# Altium schematic sheet [6]CH340C.SchDoc
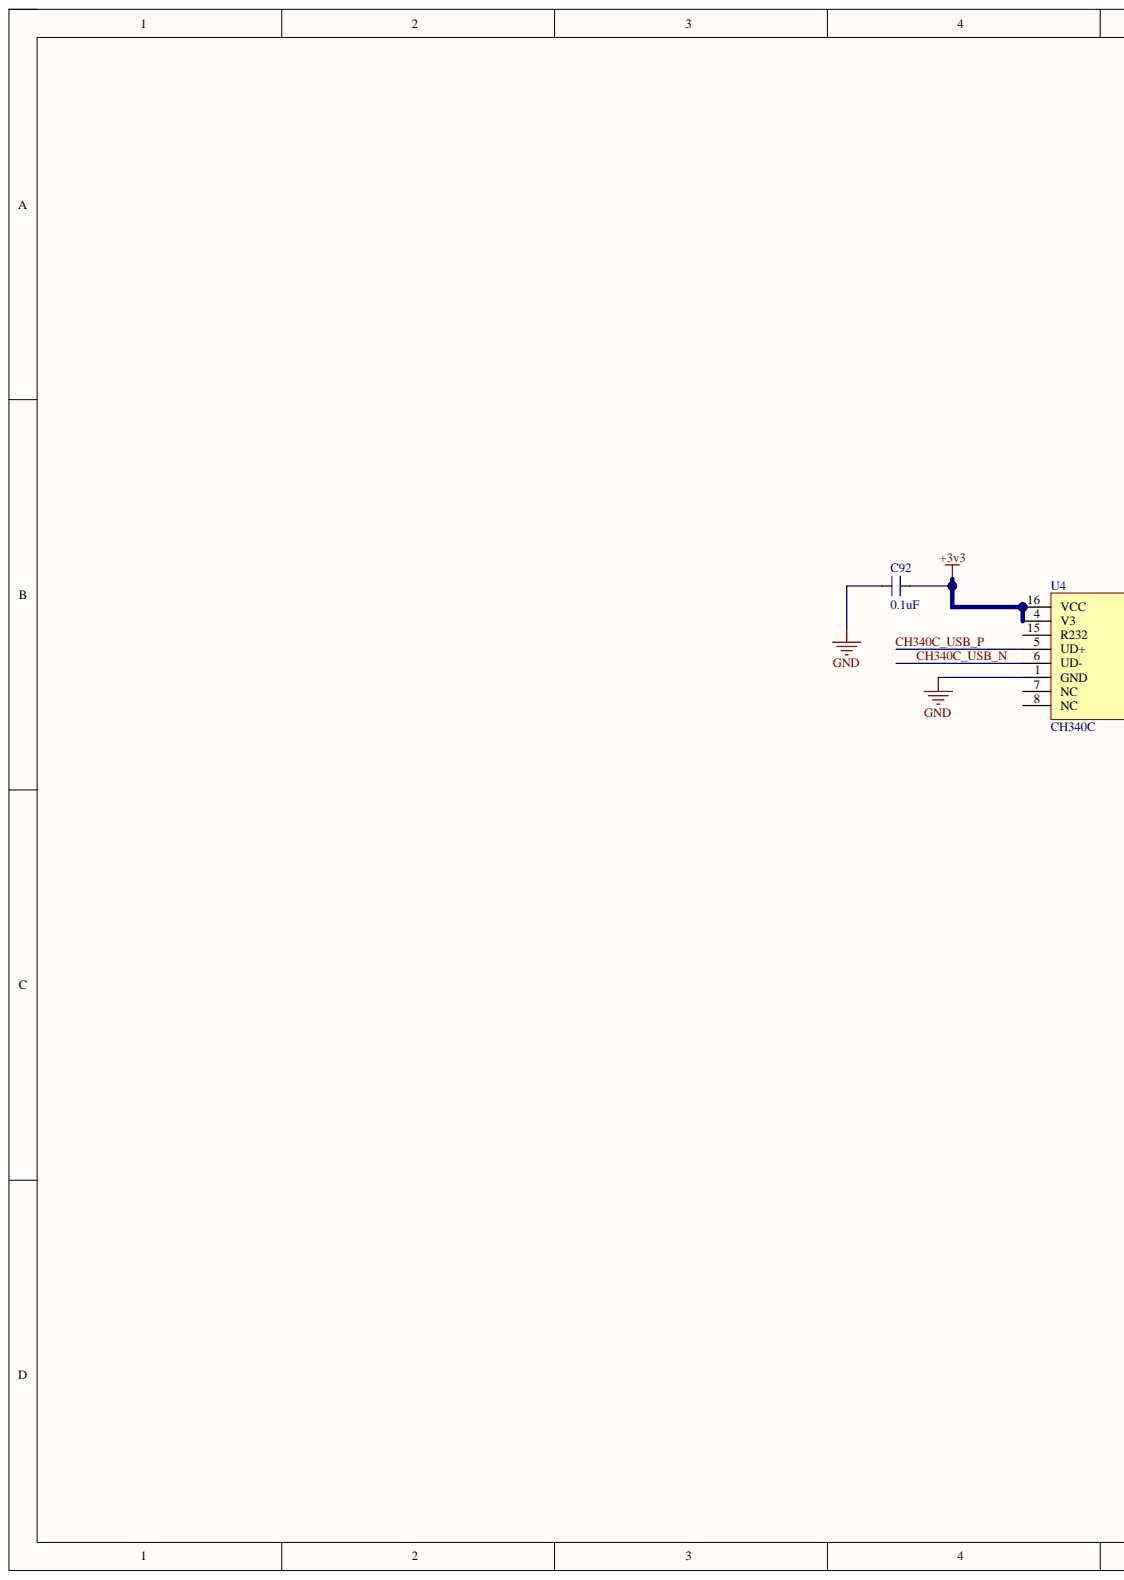
[diagram p0: [6]CH340C.SchDoc, left]
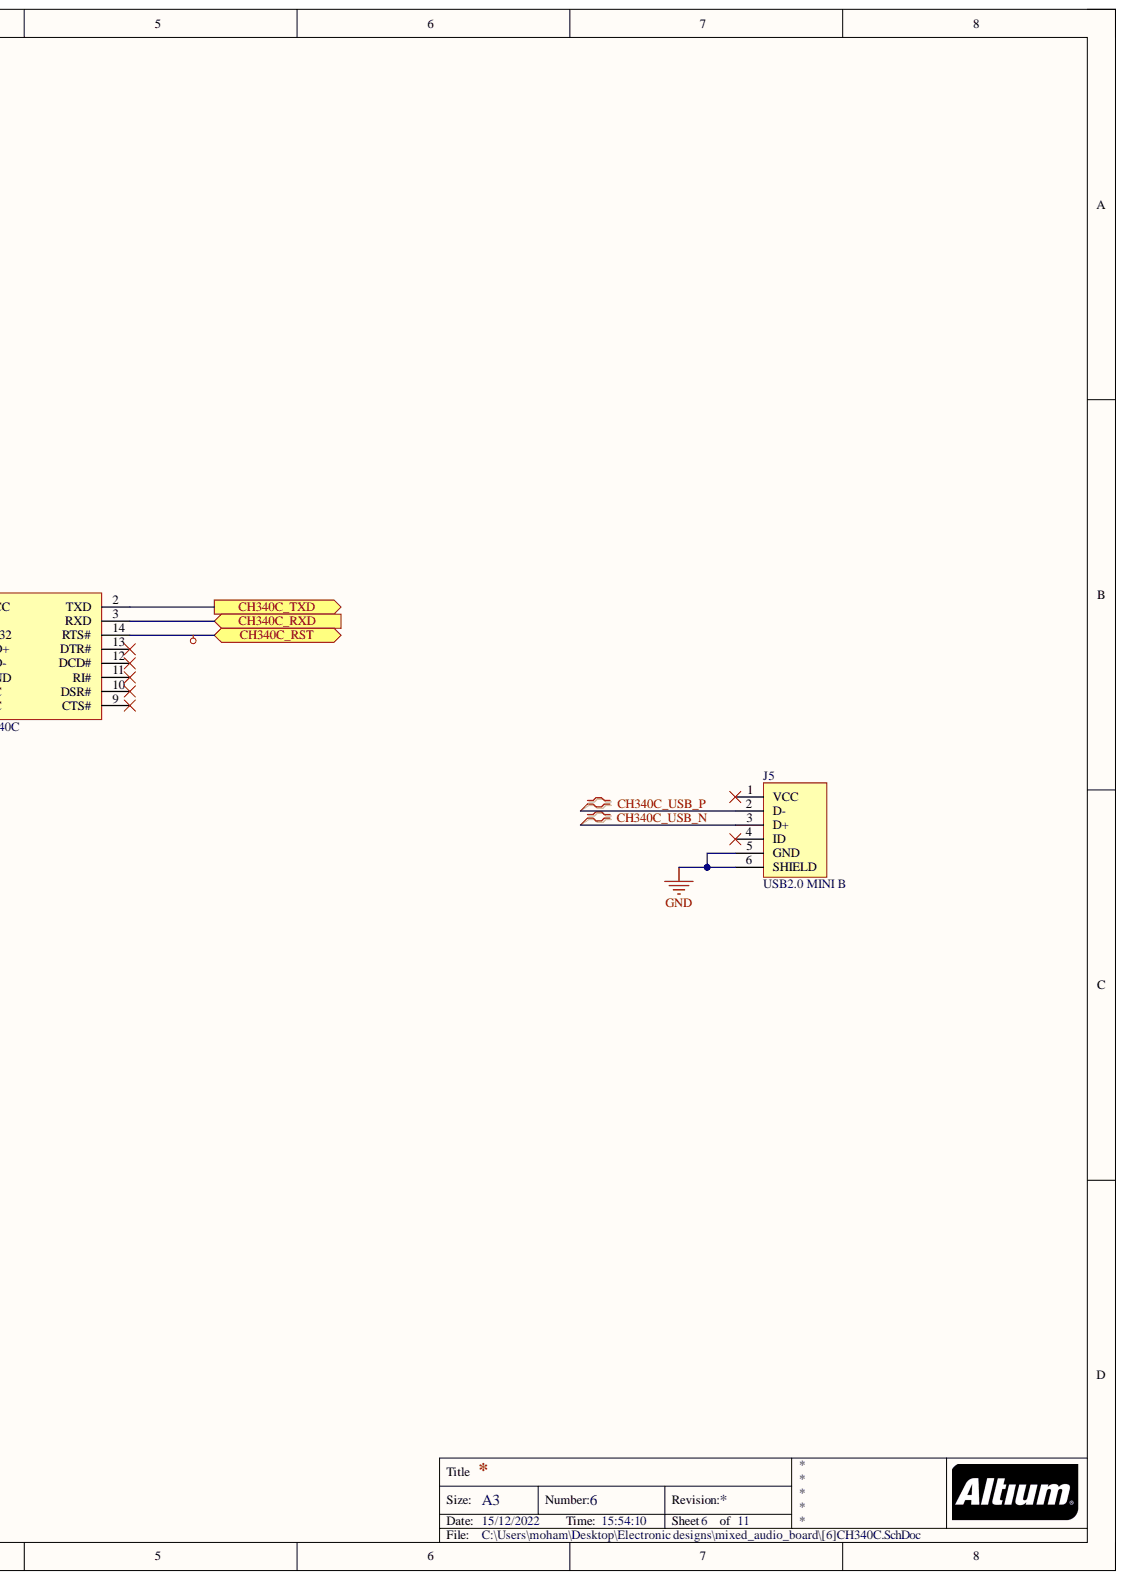
[diagram p1: [6]CH340C.SchDoc, right]

## Parts
C92  0.1uF  [CAP_0805]
J5  USB2.0 MINI B  [CON_690-005-299-043]
U4  CH340C  [SOIC16_50_284_25X90_SMT]

## Ports
CH340C_RST, CH340C_RXD, CH340C_TXD

## Nets
+3v3: C92.2 U4.4(V3) U4.16(VCC)
GND: C92.1 J5.5(GND) J5.6(SHIELD) U4.1(GND)
CH340C_RST: U4.14(RTS#) ; port CH340C_RST
CH340C_RXD: U4.3(RXD) ; port CH340C_RXD
CH340C_TXD: U4.2(TXD) ; port CH340C_TXD
CH340C_USB_N: J5.3(D+) U4.6(UD-)
CH340C_USB_P: J5.2(D-) U4.5(UD+)

Not connected: J5 1(VCC) 4(ID); U4 7(NC) 8(NC) 9(CTS#) 10(DSR#) 11(RI#) 12(DCD#) 13(DTR#) 15(R232)

## Library data of the parts
C92 = CAP_0.1uF-50V-5%-0805: 0.1µF ±5% 50V Ceramic Capacitor X7R 0805 (2012 Metric); Alternate Part Number-1: 0805B104M500; Manufacturer: AVX Corporation; Manufacturer Part Number: 08055C104JAT4A; Case/Package: 0805; Component Kind: Standard; Library Name: ESTEEMPCBLIB.IntLib; Supplier Part Number-1: 0805B104J500CT; Supplier-1: SIRICOM
J5 = CON_USB2.0-MINIB-SMD: USB - mini B USB 2.0 Receptacle Connector 5 Position Surface Mount, Right Angle; Alternate Part Number-1: NA; Manufacturer: EDAC; Manufacturer Part Number: 690-005-299-043; Case/Package: USB-MINI-B; Component Kind: Standard; Library Name: ESTEEMPCBLIB.IntLib; Supplier Part Number-1: C105424; Supplier-1: LCSC
U4 = IC_CH340C: USB Bridge, USB to UART USB 2.0 UART Interface; Alternate Part Number-1: NA; Manufacturer: Olimex; Case/Package: SOIC16; Component Kind: Standard; Library Name: ESTEEMPCBLIB.IntLib; Manufacturer Part Number: CH340C; Supplier Part Number-1: C84681; Supplier-1: LCSC
